# Altium schematic sheet Sheet1.SchDoc
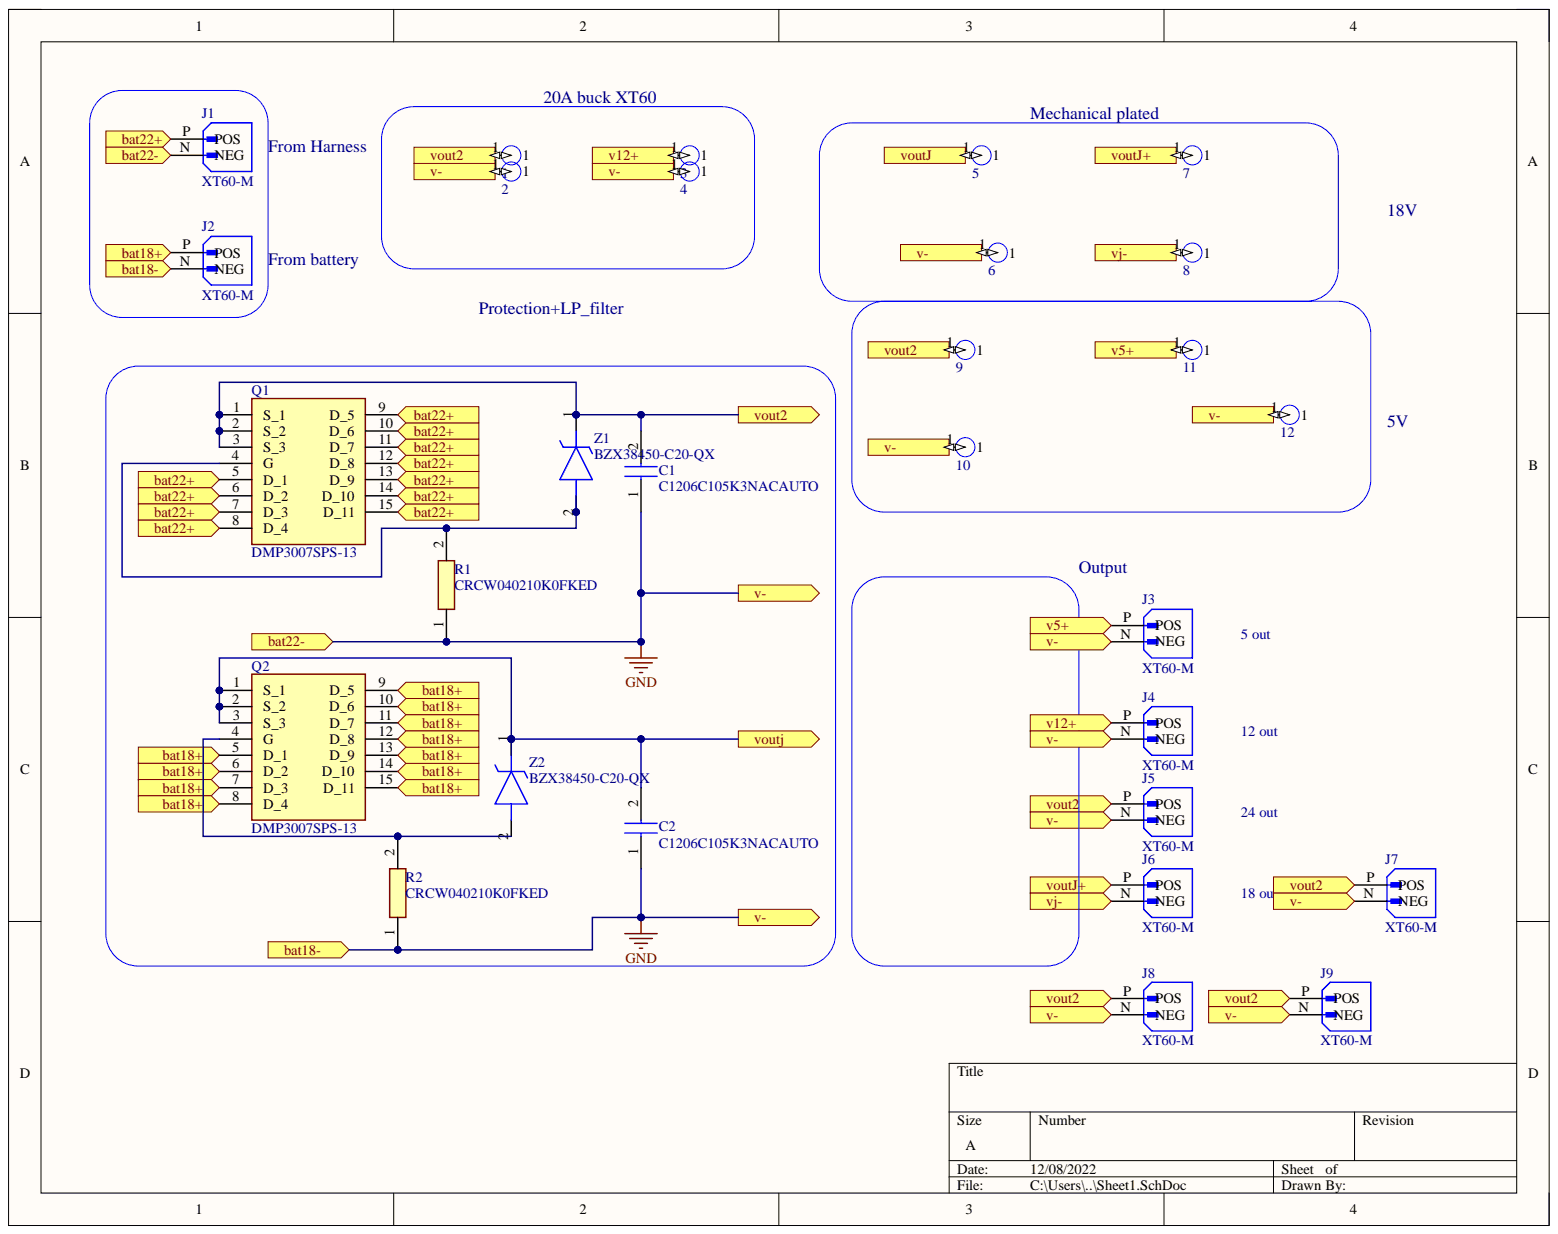
[diagram p0: Sheet1.SchDoc]

## Parts
1  mounting_h_p_10A buck  [mounting_h_p 10A buck]  (value not drawn)
2  mounting_h_p_10A buck  [mounting_h_p 10A buck]  (value not drawn)
3  mounting_h_p_10A buck  [mounting_h_p 10A buck]  (value not drawn)
4  mounting_h_p_10A buck  [mounting_h_p 10A buck]  (value not drawn)
5  mounting_h_p_10A buck  [mounting_h_p 10A buck]  (value not drawn)
6  mounting_h_p_10A buck  [mounting_h_p 10A buck]  (value not drawn)
7  mounting_h_p_10A buck  [mounting_h_p 10A buck]  (value not drawn)
8  mounting_h_p_10A buck  [mounting_h_p 10A buck]  (value not drawn)
9  mounting_h_p_10A buck  [mounting_h_p 10A buck]  (value not drawn)
10  mounting_h_p_10A buck  [mounting_h_p 10A buck]  (value not drawn)
11  mounting_h_p_10A buck  [mounting_h_p 10A buck]  (value not drawn)
12  mounting_h_p_10A buck  [mounting_h_p 10A buck]  (value not drawn)
C1  C1206C105K3NACAUTO  [C1206]
C2  C1206C105K3NACAUTO  [C1206]
J1  XT60-M  [AMASS_XT60-M]
J2  XT60-M  [AMASS_XT60-M]
J3  XT60-M  [AMASS_XT60-M]
J4  XT60-M  [AMASS_XT60-M]
J5  XT60-M  [AMASS_XT60-M]
J6  XT60-M  [AMASS_XT60-M]
J7  XT60-M  [AMASS_XT60-M]
J8  XT60-M  [AMASS_XT60-M]
J9  XT60-M  [AMASS_XT60-M]
Q1  DMP3007SPS-13  [DMP3007SPS13]
Q2  DMP3007SPS-13  [DMP3007SPS13]
R1  CRCW040210K0FKED  [RESC1005X40N]
R2  CRCW040210K0FKED  [RESC1005X40N]
Z1  BZX38450-C20-QX  [BZX38450C20QX]
Z2  BZX38450-C20-QX  [BZX38450C20QX]

## Ports
bat18+, bat18-, bat22+, bat22-, v5+, v12+, v-, vj-, vout2, voutj, voutJ, voutJ+

## Nets
GND: 2.1 4.1 6.1 10.1 12.1 C1.1 C2.1 J1.N J2.N J3.N J4.N J5.N J7.N J8.N J9.N R1.1 R2.1 ; port v-
v5+: 11.1 J3.P ; port v5+
v12+: 3.1 J4.P ; port v12+
vj-: 8.1 J6.N ; port vj-
vout2: 1.1 9.1 C1.2 J5.P J7.P J8.P J9.P Q1.1(S_1) Q1.2(S_2) Q1.3(S_3) Z1.1 ; port vout2
voutJ: 5.1 C2.2 Q2.1(S_1) Q2.2(S_2) Q2.3(S_3) Z2.1 ; port voutJ, port voutj
voutJ+: 7.1 J6.P ; port voutJ+
NetJ1_P: J1.P Q1.5(D_1) Q1.6(D_2) Q1.7(D_3) Q1.8(D_4) Q1.9(D_5) Q1.10(D_6) Q1.11(D_7) Q1.12(D_8) Q1.13(D_9) Q1.14(D_10) Q1.15(D_11)
NetJ2_P: J2.P Q2.5(D_1) Q2.6(D_2) Q2.7(D_3) Q2.8(D_4) Q2.9(D_5) Q2.10(D_6) Q2.11(D_7) Q2.12(D_8) Q2.13(D_9) Q2.14(D_10) Q2.15(D_11)
NetQ1_4: Q1.4(G) R1.2 Z1.2
NetQ2_4: Q2.4(G) R2.2 Z2.2

## Library data of the parts
C1 C2 = C1206C105K3NACAUTO: Capacitor; Manufacturer_Name: KEMET; Arrow Part Number: C1206C105K3NACAUTO; Arrow Price/Stock: https://www.arrow.com/en/products/c1206c105k3nacauto/kemet-corporation?region=nac; Component Kind: Standard; Datasheet Link: https://content.kemet.com/datasheets/KEM_C1008_X8L_150C_SMD.pdf; Library Name: SamacSys.SchLib; Manufacturer_Part_Number: C1206C105K3NACAUTO; Mouser Part Number: 80-C1206C105K3NAUTO; Mouser Price/Stock: https://www.mouser.co.uk/ProductDetail/KEMET/C1206C105K3NACAUTO?qs=KosDah%252BIqW80OcpWuLU%2FTg%3D%3D
J1-J9 = XT60-M: Plug; DC supply; XT60; male; PIN: 2; for cable; soldered; 30A; 500V; MF: AMASS; Availability: Not in stock; Check_prices: https://www.snapeda.com/parts/XT60-M/AMASS/view-part/?ref=eda; Component Kind: Standard; Library Name: XT60-M.IntLib; MP: XT60-M; Package: Package; Price: None; SnapEDA_Link: https://www.snapeda.com/parts/XT60-M/AMASS/view-part/?ref=snap
Q1 Q2 = DMP3007SPS-13: MOSFET (P-Channel); Manufacturer_Name: Diodes Inc.; Arrow Part Number: DMP3007SPS-13; Arrow Price/Stock: https://www.arrow.com/en/products/dmp3007sps-13/diodes-incorporated; Component Kind: Standard; Datasheet Link: https://www.diodes.com/assets/Datasheets/DMP3007SPS.pdf; Height: 1.1mm; Manufacturer_Part_Number: DMP3007SPS-13; Mouser Part Number: 621-DMP3007SPS-13; Mouser Price/Stock: https://www.mouser.co.uk/ProductDetail/Diodes-Incorporated/DMP3007SPS-13?qs=lqAf%2FiVYw9gUw3j1lYiG9g%3D%3D
R1 R2 = CRCW040210K0FKED: Resistor; Manufacturer_Name: Vishay; Arrow Part Number: CRCW040210K0FKED; Arrow Price/Stock: https://www.arrow.com/en/products/crcw040210k0fked/vishay?region=nac; Component Kind: Standard; Datasheet Link: http://www.vishay.com/docs/20035/dcrcwe3.pdf; Height: 0.4mm; Manufacturer_Part_Number: CRCW040210K0FKED; Mouser Part Number: 71-CRCW0402-10K-E3; Mouser Price/Stock: https://www.mouser.co.uk/ProductDetail/Vishay-Dale/CRCW040210K0FKED?qs=rlE3Te1NifyN3e8vvxacSw%3D%3D
Z1 Z2 = BZX38450-C20-QX: Zener Diode; Manufacturer_Name: Nexperia; Component Kind: Standard; Datasheet Link: https://assets.nexperia.com/documents/data-sheet/BZX38450-Q_SER.pdf; Height: 1.1mm; Manufacturer_Part_Number: BZX38450-C20-QX; Mouser Part Number: 771-BZX38450-C20-QX; Mouser Price/Stock: https://www.mouser.co.uk/ProductDetail/Nexperia/BZX38450-C20-QX?qs=7D1LtPJG0i066wYTm2%252BdVQ%3D%3D
1-12 = mounting_h_p_10A buck: Component Kind: Standard; Library Name: Schlib1.SchLib
